# Altium schematic sheet shift_relays.SchDoc
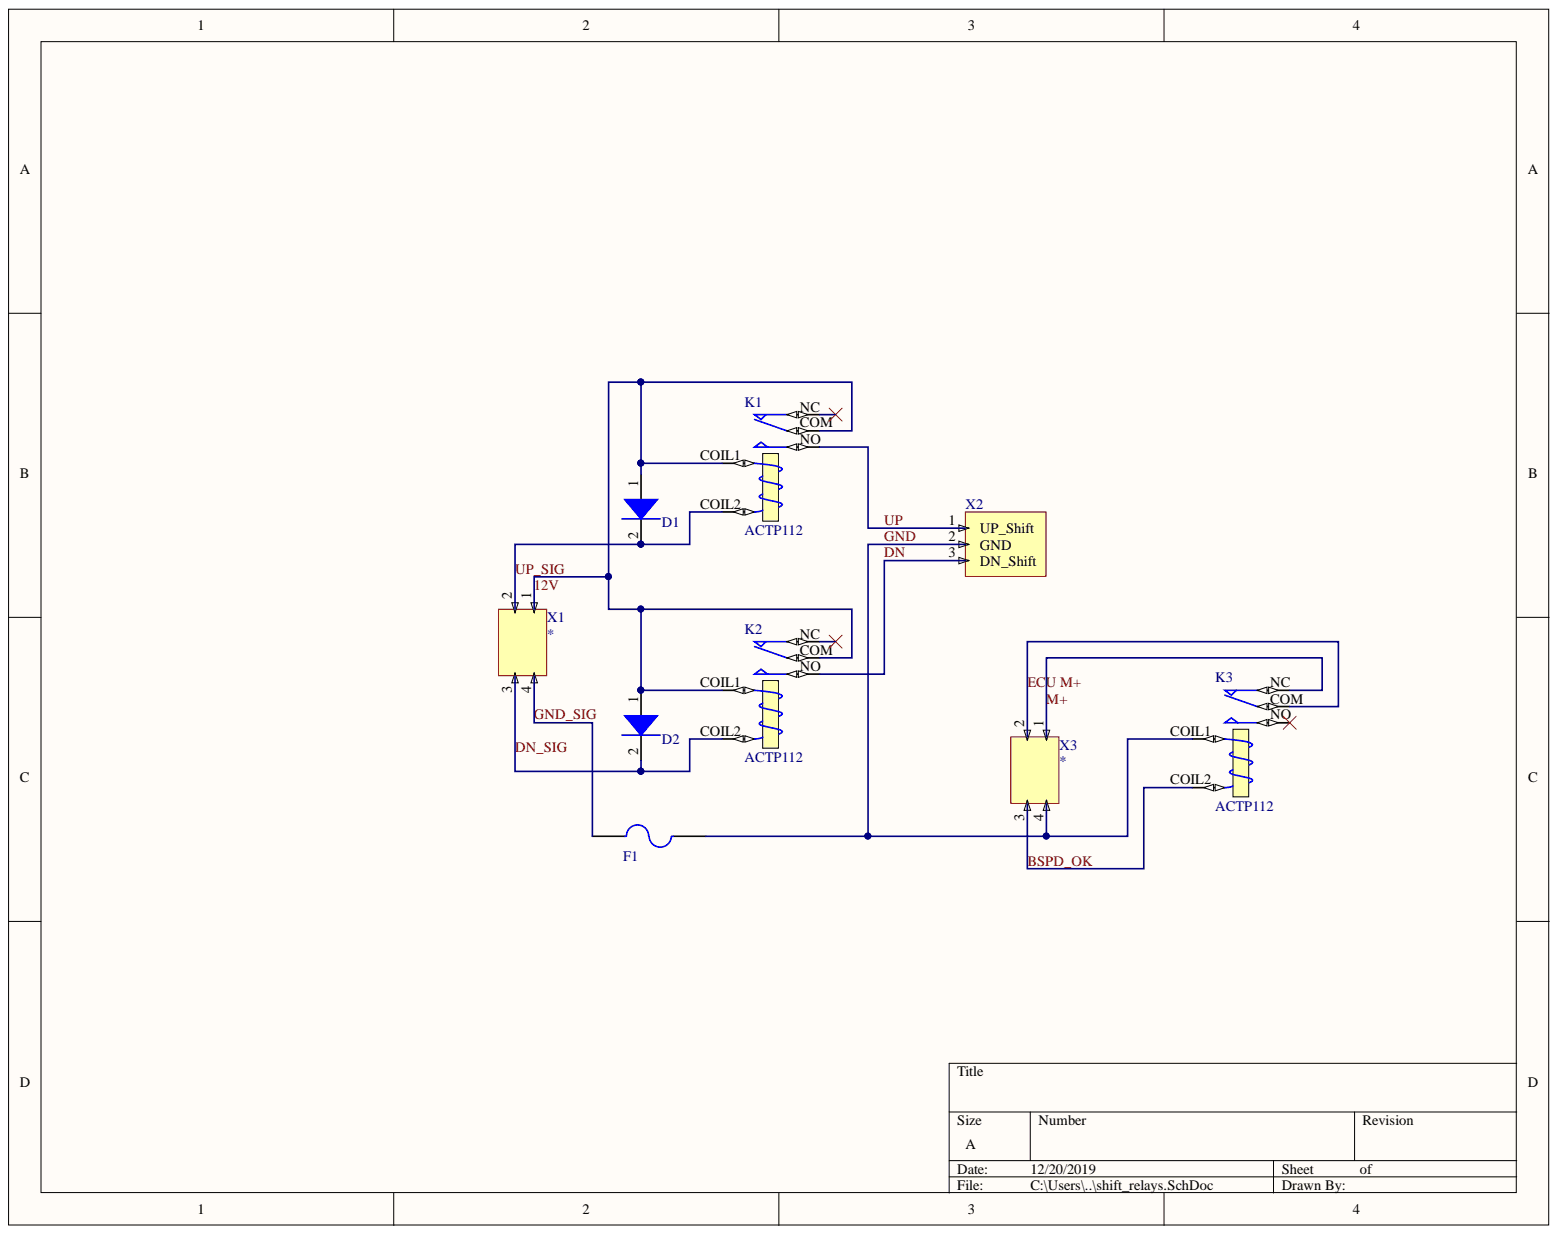
[diagram p0: shift_relays.SchDoc]

## Parts
D1  (no value)  [SOD123F]
D2  (no value)  [SOD123F]
F1  Fuse  [3568-MiniFuseHolder]  (value not drawn)
K1  ACTP112  [ACTP112]
K2  ACTP112  [ACTP112]
K3  ACTP112  [ACTP112]
X1  (no value)  [DTF13-4P]
X2  =MfrPartNo  [CONN_Shift]
X3  (no value)  [DTF13-4P]

## Nets
12V: D1.1 D2.1 K1.COIL1 K1.COM K2.COIL1 K2.COM X1.1
BSPD_OK: K3.COIL2 X3.3
DN: K2.NO X2.3
DN_SIG: D2.2 K2.COIL2 X1.3
ECU M+: K3.COM X3.2
GND: F1.2 K3.COIL1 X2.2 X3.4
GND_SIG: F1.1 X1.4
M+: K3.NC X3.1
UP: K1.NO X2.1
UP_SIG: D1.2 K1.COIL2 X1.2

Not connected: K1 NC; K2 NC; K3 NO

## Library data of the parts
D1 D2 = 2: Diode Super Barrier 40V 1A Surface Mount SOD-; Database Table Name: diodes; name: 1N5819; Component Kind: Standard; id: 2; link: https://www.digikey.com/product-detail/en/diodes-incorporated/1N5819HW1-7-F/1N5819HW1-7-FDITR-ND/5768523; package: SOD-123; quantity: 0; Library Name: altlib.DbLib
F1 = 3: Database Table Name: fuses; iinterrupt: 0; Name: 3568 Mini Fuse Holder; Component Kind: Standard; id: 3; itrip: 0; link: https://www.digikey.com/product-detail/en/keystone-electronics/3568/36-3568-ND/2137306; quantity: 0; vmax: 0; Library Name: altlib.DbLib
K1-K3 = 1: current: 0; Database Table Name: relays; voltage: 12; Component Kind: Standard; id: 1; LatestRevisionDate: 17-Jul-2002; LatestRevisionNote: Re-released for DXP Platform.; link: https://www.digikey.com/product-detail/en/panasonic-electric-works/ACTP112/255-5163-ND/4691838; name: ACTP112; PackageReference: MODULE5B; Published: 8-Jun-2000; quantity: 0; createdby: Kevin; Library Name: altlib.DbLib; Publisher: Altium Limited
X1 X3 = 15: DTF13-4P; Database Table Name: connectors; numcontacts: 4; Component Kind: Standard; id: 15; link: https://www.te.com/usa-en/product-DTF13-4P.html; name: DTF13-4P; quantity: 0; Library Name: altlib.DbLib
X2 = 31: Connector for Shift Relays; Database Table Name: connectors; name: CONN Shift; numcontacts: 3; Component Kind: Standard; id: 31; quantity: 0; createdby: Patrick; Library Name: altlib.DbLib
